# Altium schematic sheet Sheet2.SchDoc
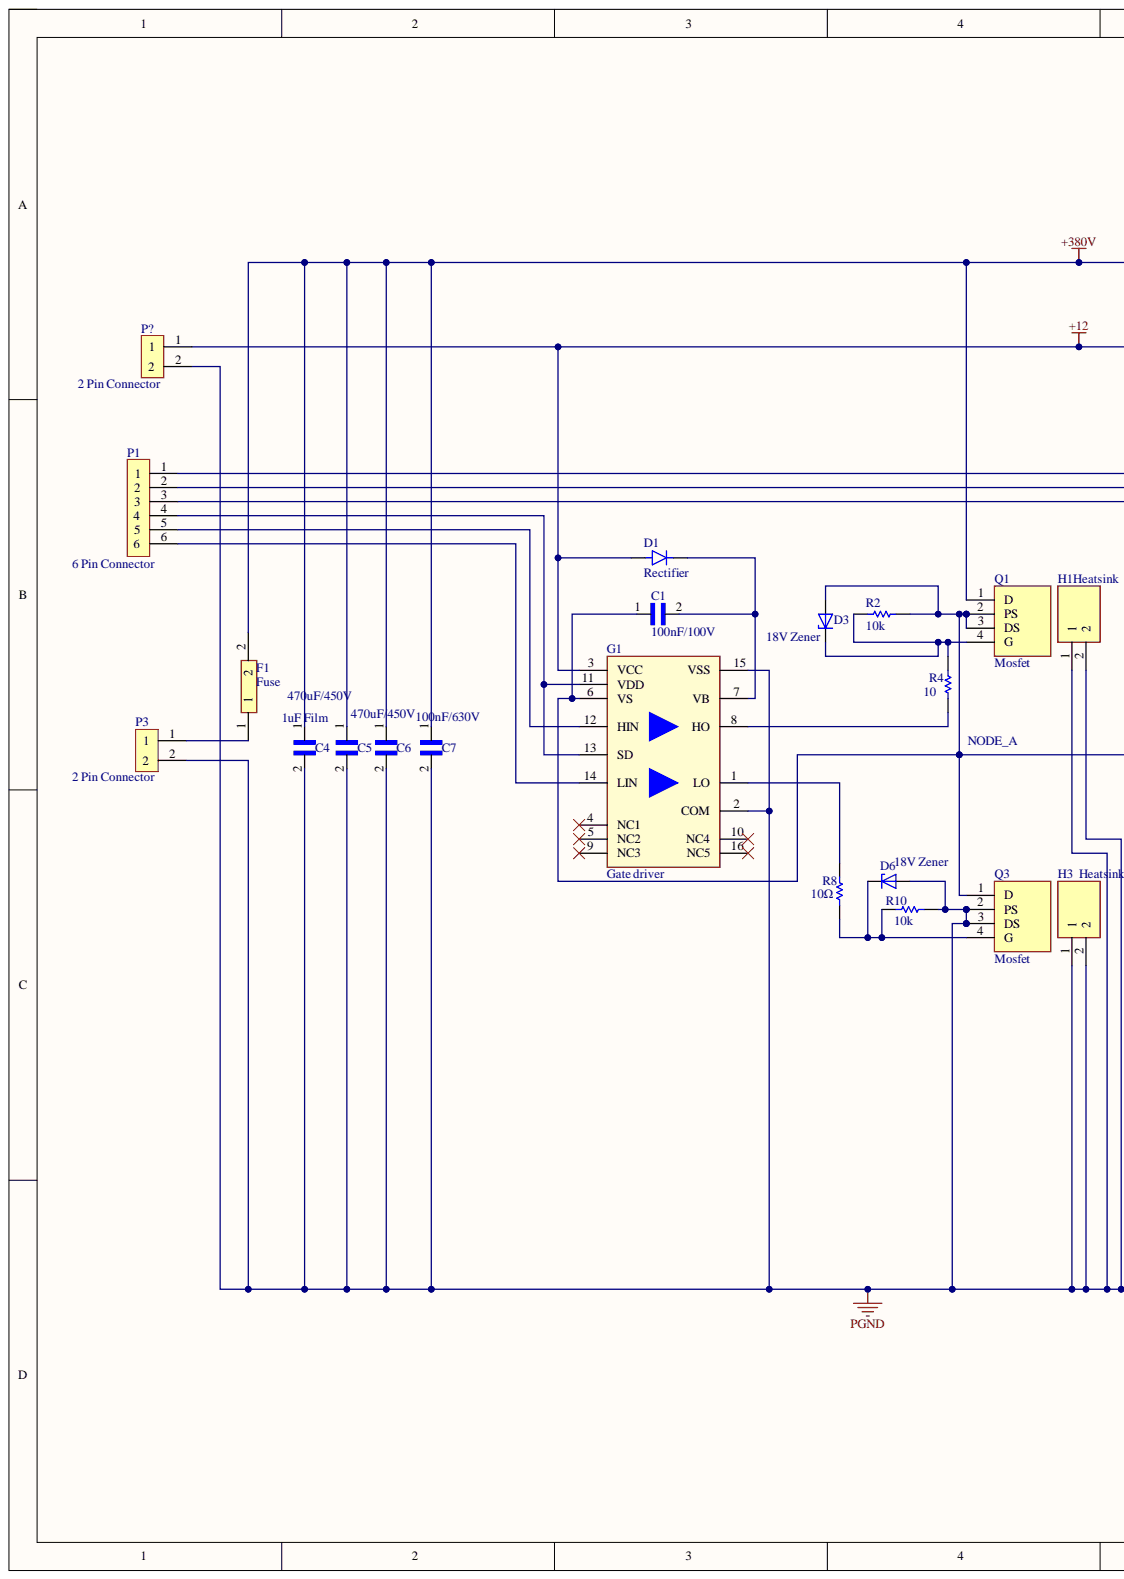
[diagram p0: Sheet2.SchDoc, left]
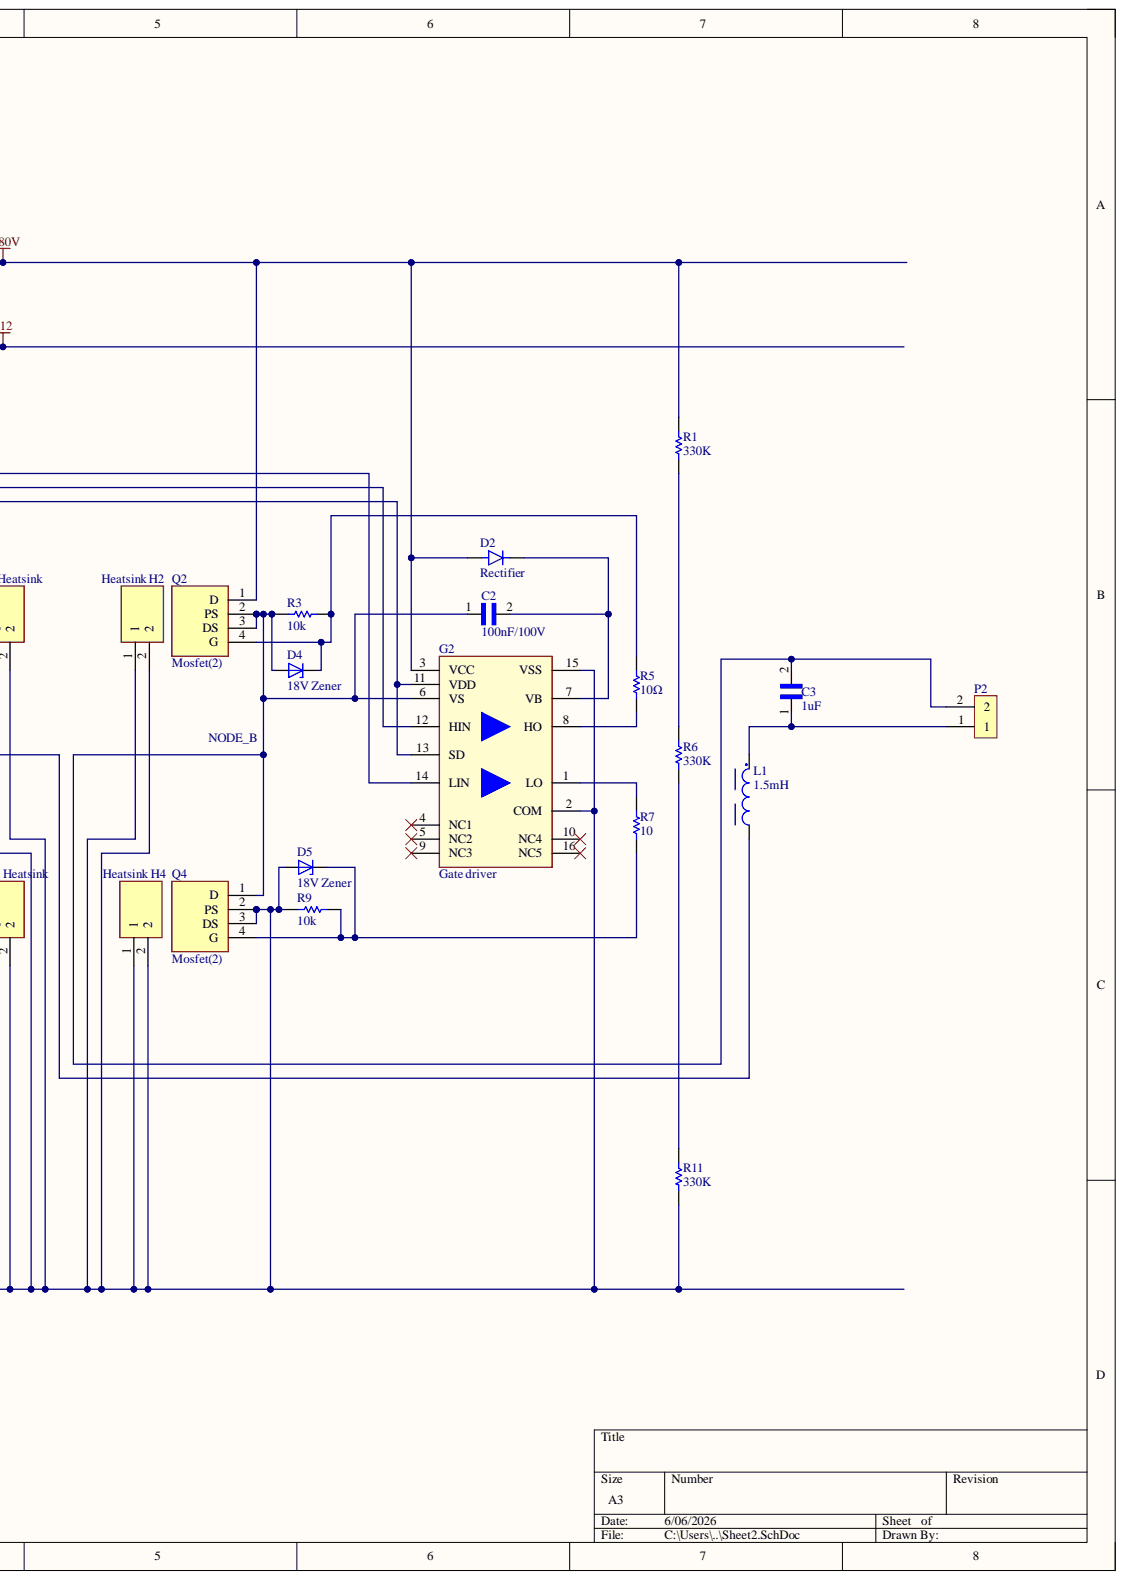
[diagram p1: Sheet2.SchDoc, right]

## Parts
*  330K  (value not drawn)
C1  100nF/100V
C2  100nF/100V
C3  1uF
C4  1uF Film
C5  470uF/450V
C6  470uF/450V
C7  100nF/630V
D1  Rectifier
D2  Rectifier
D3  18V Zener
D4  18V Zener
D5  18V Zener
D6  18V Zener
F1  Fuse
G1  Gate driver
G2  Gate driver
H1  Heatsink
H2  Heatsink
H3  Heatsink
H4  Heatsink
L1  1.5mH
P1  6 Pin Connector
P2  (no value)
P3  2 Pin Connector
P?  2 Pin Connector
Q1  Mosfet
Q2  Mosfet(2)
Q3  Mosfet
Q4  Mosfet(2)
R1  330K
R2  10k
R3  10k
R4  10
R5  10Ω
R6  330K
R7  10
R8  10Ω
R9  10k
R10  10k
R11  330K

## Nets
+12: D1.A G1.3(VCC) P?.1
+380V: C4.1 C5.1 C6.1 C7.1 D2.A F1.2 G2.3(VCC) Q1.1(D) Q2.1(D) R1.2
PGND: C4.2 C5.2 C6.2 C7.2 D5.2 D6.2 G1.2(COM) G1.15(VSS) G2.2(COM) G2.15(VSS) H1.1 H1.2 H2.1 H2.2 H3.1 H3.2 H4.1 H4.2 P3.2 P?.2 Q3.2(PS) Q3.3(DS) Q4.2(PS) Q4.3(DS) R9.1 R10.2 R11.1
NetC1_1: C1.1 D3.2 G1.6(VS) L1.1 Q1.2(PS) Q1.3(DS) Q3.1(D) R2.2
NetC1_2: C1.2 D1.C G1.7(VB)
NetC2_1: C2.1 C3.2 D4.2 G2.6(VS) P2.2 Q2.2(PS) Q2.3(DS) Q4.1(D) R3.1
NetC2_2: C2.2 D2.C G2.7(VB)
NetC3_1: C3.1 L1.2 P2.1
NetD3_1: D3.1 Q1.4(G) R2.1 R4.2
NetD4_1: D4.1 Q2.4(G) R3.2 R5.2
NetD5_1: D5.1 Q4.4(G) R7.1 R9.2
NetD6_1: D6.1 Q3.4(G) R8.1 R10.1
NetF1_1: F1.1 P3.1
NetG1_1: G1.1(LO) R8.2
NetG1_8: G1.8(HO) R4.1
NetG1_11: G1.11(VDD) G1.13(SD) P1.4
NetG1_12: G1.12(HIN) P1.5
NetG1_14: G1.14(LIN) P1.6
NetG2_1: G2.1(LO) R7.2
NetG2_8: G2.8(HO) R5.1
NetG2_11: G2.11(VDD) G2.13(SD) P1.3
NetG2_12: G2.12(HIN) P1.2
NetG2_14: G2.14(LIN) P1.1
NetR1_1: R1.1 R6.2
NetR6_1: R6.1 R11.2

Not connected: * 1 2; G1 4(NC1) 5(NC2) 9(NC3) 10(NC4) 16(NC5); G2 4(NC1) 5(NC2) 9(NC3) 10(NC4) 16(NC5)

## Library data of the parts
C3 = 1uF: 1uF  275VAC X2 Film Capacitor; Component Kind: Standard; Library Name: Schlib2.SchLib
D1 D2 = Rectifier: UF4007; Component Kind: Standard; Library Name: Schlib2.SchLib
D3-D6 = Zener Diode: BZT52C18; Component Kind: Standard; Library Name: Schlib2.SchLib
G1 G2 = Gate driver: IR2110; Component Kind: Standard; Library Name: Schlib2.SchLib
L1 = Inductor: Component Kind: Standard; Library Name: Schlib2.SchLib
P2 = 2 Pin Connector: Component Kind: Standard; Library Name: Schlib2.SchLib
Q1 Q3 = Mosfet: C3M0045065K; Component Kind: Standard; Library Name: Schlib2.SchLib
Q2 Q4 = Mosfet(2): C3M0045065K; Component Kind: Standard; Library Name: Schlib2.SchLib
R4 R7 = 10Ω: Component Kind: Standard; Library Name: Schlib2.SchLib
* R1 R6 R11 = 330K: Component Kind: Standard; Library Name: Schlib2.SchLib
C1 C2 = 100nF/100V: Component Kind: Standard; Library Name: Schlib2.SchLib
C4 = 1uF Film: Component Kind: Standard; Library Name: Schlib2.SchLib
C5 C6 = 470uF/450V: Component Kind: Standard; Library Name: Schlib2.SchLib
C7 = 100nF/630V: Component Kind: Standard; Library Name: Schlib2.SchLib
F1 = Fuse: Component Kind: Standard; Library Name: Schlib2.SchLib
H1-H4 = Heatsink: Component Kind: Standard; Library Name: Schlib2.SchLib
P1 = 6 Pin Connector: Component Kind: Standard; Library Name: Schlib2.SchLib
P3 P? = 2 Pin Connector: Component Kind: Standard; Library Name: Schlib2.SchLib
R2 R3 R9 R10 = 10k: Component Kind: Standard; Library Name: Schlib2.SchLib
R5 R8 = 10Ω: Component Kind: Standard; Library Name: Schlib2.SchLib
